# Altium schematic sheet Micro.SchDoc(Micro)
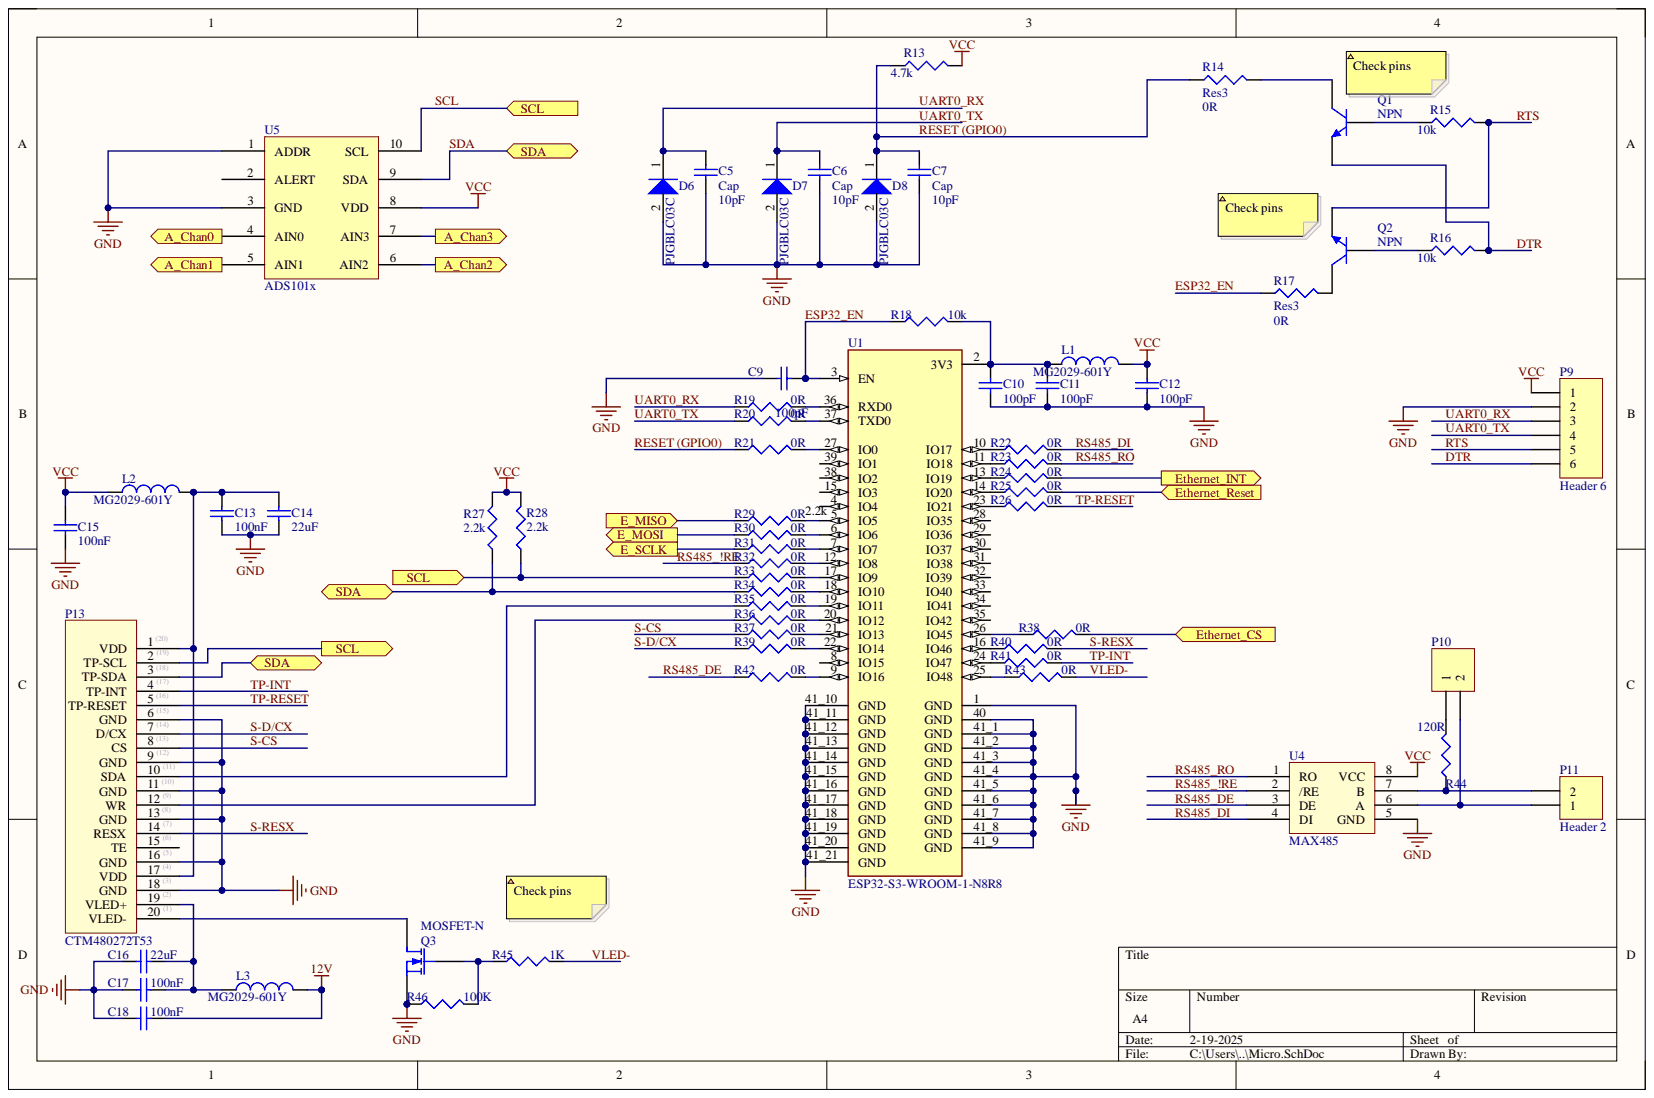
[diagram p0: Micro.SchDoc]

## Parts
C5  Cap  value 10pF  [CAPC2012X04M]
C6  Cap  value 10pF  [CAPC2012X04M]
C7  Cap  value 10pF  [CAPC2012X04M]
C9  value 100pF  [CAPC2012X04M]  (hidden comment: Cap)
C10  value 100pF  [CAPC2012X04M]  (hidden comment: Cap)
C11  value 100pF  [CAPC2012X04M]  (hidden comment: Cap)
C12  value 100pF  [CAPC2012X04M]  (hidden comment: Cap)
C13  value 100nF  [CAPC2012X04M]  (hidden comment: Cap)
C14  value 22uF  [CAPC2012X04M]  (hidden comment: Cap)
C15  value 100nF  [CAPC2012X04M]  (hidden comment: Cap)
C16  value 22uF  [CAPC2012X04M]  (hidden comment: Cap)
C17  value 100nF  [CAPC2012X04M]  (hidden comment: Cap)
C18  value 100nF  [CAPC2012X04M]  (hidden comment: Cap)
D6  PJGBLC03C  [DIOC2012X04M]
D7  PJGBLC03C  [DIOC2012X04M]
D8  PJGBLC03C  [DIOC2012X04M]
L1  value MG2029-601Y  [RESC2012X04M]  (hidden comment: Inductor)
L2  value MG2029-601Y  [RESC2012X04M]  (hidden comment: Inductor)
L3  value MG2029-601Y  [RESC2012X04M]  (hidden comment: Inductor)
P9  Header 6  [HDR1X6]
P10  Header 2  [HDR1X2]  (value not drawn)
P11  Header 2  [WURTH- WR-TBL 2pin 5mmpitch]
P13  CTM480272T53  [CF31201D0R0-05-NH]
Q1  NPN  [SOT95P245X110-3N]
Q2  NPN  [SOT95P245X110-3N]
Q3  MOSFET-N  [SOT95P245X110-3N]
R13  value 4.7k  [RESC2012X04M]  (hidden comment: Res3)
R14  Res3  value 0R  [RESC2012X04M]
R15  value 10k  [RESC2012X04M]  (hidden comment: Res3)
R16  value 10k  [RESC2012X04M]  (hidden comment: Res3)
R17  Res3  value 0R  [RESC2012X04M]
R18  value 10k  [RESC2012X04M]  (hidden comment: Res3)
R19  value 0R  [RESC2012X04M]  (hidden comment: Res3)
R20  value 0R  [RESC2012X04M]  (hidden comment: Res3)
R21  value 0R  [RESC2012X04M]  (hidden comment: Res3)
R22  value 0R  [RESC2012X04M]  (hidden comment: Res3)
R23  value 0R  [RESC2012X04M]  (hidden comment: Res3)
R24  value 0R  [RESC2012X04M]  (hidden comment: Res3)
R25  value 0R  [RESC2012X04M]  (hidden comment: Res3)
R26  value 0R  [RESC2012X04M]  (hidden comment: Res3)
R27  value 2.2k  [RESC2012X04M]  (hidden comment: Res3)
R28  value 2.2k  [RESC2012X04M]  (hidden comment: Res3)
R29  value 0R  [RESC2012X04M]  (hidden comment: Res3)
R30  value 0R  [RESC2012X04M]  (hidden comment: Res3)
R31  value 0R  [RESC2012X04M]  (hidden comment: Res3)
R32  value 0R  [RESC2012X04M]  (hidden comment: Res3)
R33  value 0R  [RESC2012X04M]  (hidden comment: Res3)
R34  value 0R  [RESC2012X04M]  (hidden comment: Res3)
R35  value 0R  [RESC2012X04M]  (hidden comment: Res3)
R36  value 0R  [RESC2012X04M]  (hidden comment: Res3)
R37  value 0R  [RESC2012X04M]  (hidden comment: Res3)
R38  value 0R  [RESC2012X04M]  (hidden comment: Res3)
R39  value 0R  [RESC2012X04M]  (hidden comment: Res3)
R40  value 0R  [RESC2012X04M]  (hidden comment: Res3)
R41  value 0R  [RESC2012X04M]  (hidden comment: Res3)
R42  value 0R  [RESC2012X04M]  (hidden comment: Res3)
R43  value 0R  [RESC2012X04M]  (hidden comment: Res3)
R44  value 120R  [RESC2012X04M]  (hidden comment: Res3)
R45  value 1K  [RESC2012X04M]  (hidden comment: Res3)
R46  value 100K  [RESC2012X04M]  (hidden comment: Res3)
U1  ESP32-S3-WROOM-1-N8R8  [XCVR_ESP32S3WROOM1N8R8]
U4  MAX485  [SOIC127P600X155-8N]
U5  ADS101x  [VSSOP_10]

## Ports
A_Chan0, A_Chan1, A_Chan2, A_Chan3, E_MISO, E_MOSI, E_SCLK, Ethernet_CS, Ethernet_INT, Ethernet_Reset, SCL, SDA

## Nets
12V: C18.1 L3.2
GND: C5.1 C6.1 C7.1 C9.2 C10.2 C11.2 C12.2 C13.2 C14.2 C15.2 C16.2 C17.2 C18.2 D6.2 D7.2 D8.2 P9.2 P13.3(GND) P13.5(GND) P13.8(GND) P13.10(GND) P13.12(GND) P13.15(GND) Q3.3 R46.1 U1.1(GND) U1.40(GND) U1.41_1(GND) U1.41_2(GND) U1.41_3(GND) U1.41_4(GND) U1.41_5(GND) U1.41_6(GND) U1.41_7(GND) U1.41_8(GND) U1.41_9(GND) U1.41_10(GND) U1.41_11(GND) U1.41_12(GND) U1.41_13(GND) U1.41_14(GND) U1.41_15(GND) U1.41_16(GND) U1.41_17(GND) U1.41_18(GND) U1.41_19(GND) U1.41_20(GND) U1.41_21(GND) U4.5(GND) U5.1(ADDR) U5.3(GND)
VCC: C12.1 C15.1 L1.2 L2.1 P9.1 R13.2 R27.2 R28.2 U4.8(VCC) U5.8(VDD)
A_Chan0: U5.4(AIN0) ; port A_Chan0
A_Chan1: U5.5(AIN1) ; port A_Chan1
A_Chan2: U5.6(AIN2) ; port A_Chan2
A_Chan3: U5.7(AIN3) ; port A_Chan3
DTR: P9.6 Q1.3 R16.2
E_MISO: R29.1 ; port E_MISO
E_MOSI: R30.1 ; port E_MOSI
E_SCLK: R31.1 ; port E_SCLK
ESP32_EN: C9.1 R17.1 R18.1 U1.3(EN)
Ethernet_CS: R38.2 ; port Ethernet_CS
Ethernet_INT: R24.2 ; port Ethernet_INT
Ethernet_Reset: R25.2 ; port Ethernet_Reset
RESET (GPIO0): C7.2 D8.1 R13.1 R14.1 R21.1
RS485_!RE: R32.1 U4.2(/RE)
RS485_DE: R42.1 U4.3(DE)
RS485_DI: R22.2 U4.4(DI)
RS485_RO: R23.2 U4.1(RO)
RTS: P9.5 Q2.3 R15.2
S-CS: P13.13(CS) R37.1
S-D/CX: P13.14(D/CX) R39.1
S-RESX: P13.7(RESX) R40.2
SCL: P13.19(TP-SCL) R28.1 R33.1 U5.10(SCL) ; port SCL
SDA: P13.18(TP-SDA) R27.1 R34.1 U5.9(SDA) ; port SDA
TP-INT: P13.17(TP-INT) R41.2
TP-RESET: P13.16(TP-RESET) R26.2
UART0_RX: C5.2 D6.1 P9.3 R19.1
UART0_TX: C6.2 D7.1 P9.4 R20.1
VLED-: R43.2 R45.2
NetC10_1: C10.1 C11.1 L1.1 R18.2 U1.2(3V3)
NetC13_1: C13.1 C14.1 L2.2 P13.4(VDD) P13.20(VDD)
NetC16_1: C16.1 C17.1 L3.1 P13.2(VLED+)
NetP10_1: P10.1 R44.2
NetP10_2: P10.2 P11.1 U4.6(A)
NetP11_2: P11.2 R44.1 U4.7(B)
NetP13_1: P13.1(VLED-) Q3.1
NetP13_9: P13.9(WR) R36.1
NetP13_11: P13.11(SDA) R35.1
NetQ1_1: Q1.1 R14.2
NetQ1_2: Q1.2 R15.1
NetQ2_1: Q2.1 R17.2
NetQ2_2: Q2.2 R16.1
NetQ3_2: Q3.2 R45.1 R46.2
NetR19_2: R19.2 U1.36(RXD0)
NetR20_2: R20.2 U1.37(TXD0)
NetR21_2: R21.2 U1.27(IO0)
NetR22_1: R22.1 U1.10(IO17)
NetR23_1: R23.1 U1.11(IO18)
NetR24_1: R24.1 U1.13(IO19)
NetR25_1: R25.1 U1.14(IO20)
NetR26_1: R26.1 U1.23(IO21)
NetR29_2: R29.2 U1.5(IO5)
NetR30_2: R30.2 U1.6(IO6)
NetR31_2: R31.2 U1.7(IO7)
NetR32_2: R32.2 U1.12(IO8)
NetR33_2: R33.2 U1.17(IO9)
NetR34_2: R34.2 U1.18(IO10)
NetR35_2: R35.2 U1.19(IO11)
NetR36_2: R36.2 U1.20(IO12)
NetR37_2: R37.2 U1.21(IO13)
NetR38_1: R38.1 U1.26(IO45)
NetR39_2: R39.2 U1.22(IO14)
NetR40_1: R40.1 U1.16(IO46)
NetR41_1: R41.1 U1.24(IO47)
NetR42_2: R42.2 U1.9(IO16)
NetR43_1: R43.1 U1.25(IO48)

Not connected: P13 6(TE); U1 4(IO4) 8(IO15) 15(IO3) 28(IO35) 29(IO36) 30(IO37) 31(IO38) 32(IO39) 33(IO40) 34(IO41) 35(IO42) 38(IO2) 39(IO1); U5 2(ALERT)

## Library data of the parts
C5-C7 C10-C18 = Cap: Capacitor; Component Kind: Standard; LatestRevisionDate: 17-Jul-2002; LatestRevisionNote: Re-released for DXP Platform.; Library Name: Miscellaneous Devices.IntLib; PackageDescription: Radial Cap, Thru-Hole; 2 Leads; 0.3 in Pin Spacing; PackageReference: RAD-0.3; Published: 8-Jun-2000; Signal Integrity: Cap; Simulation: CAP; Publisher: Altium Limited
C9 = Cap: Capacitor; Value: 100pF; Component Kind: Standard; LatestRevisionDate: 17-Jul-2002; LatestRevisionNote: Re-released for DXP Platform.; Library Name: Miscellaneous Devices.IntLib; PackageDescription: Radial Cap, Thru-Hole; 2 Leads; 0.3 in Pin Spacing; PackageReference: RAD-0.3; Published: 8-Jun-2000; Signal Integrity: Cap; Simulation: CAP; Publisher: Altium Limited
D6-D8 = SP4021-01FTG: Manufacturer: Littelfuse; Component Kind: Standard; Library Name: Diodes-TVS.SchLib; Mounting Technology: Surface Mount; PackageReference: SOD-323-2; PartNumber: SP4021-01FTG
L1-L3 = Inductor: Code_IEC: 1005; Code_JEITA: 0402; Component Kind: Standard; Description: Inductor; LatestRevisionDate: 29-May-2009; LatestRevisionNote: IPC-7351 Footprint Added.; Library Name: Miscellaneous Devices.IntLib; PackageDescription: Chip Inductor; PackageReference: 0402-A; Published: 8-Jun-2000; Signal Integrity: Inductor; Simulation: INDUCTOR; Publisher: Altium Limited
P9 = Header 6: Header, 6-Pin; Component Kind: Standard; LatestRevisionDate: 17-Jul-2002; LatestRevisionNote: Re-released for DXP Platform.; Library Name: Miscellaneous Connectors.IntLib; Signal Integrity: Connector; Publisher: Altium Limited
P10 P11 = Header 2: Header, 2-Pin; Component Kind: Standard; LatestRevisionDate: 17-Jul-2002; LatestRevisionNote: Re-released for DXP Platform.; Library Name: Miscellaneous Connectors.IntLib; Signal Integrity: Connector; Publisher: Altium Limited
Q1 Q2 = NPN: NPN Bipolar Transistor; Code_JEDEC: TO-226-AA; Component Kind: Standard; LatestRevisionDate: 17-Jul-2002; LatestRevisionNote: Re-released for DXP Platform.; Library Name: Miscellaneous Devices.IntLib; PackageDescription: TO, Flat Index; 3 In-Line, Axial Leads; Body Dia. 4.8mm; Leads 0.55 x 0.51 mm (max); PackageReference: TO-226-AA; Published: 8-Jun-2000; Signal Integrity: NPN; Simulation: NPN; Publisher: Altium Limited
Q3 = MOSFET-N: N-Channel MOSFET; Component Kind: Standard; LatestRevisionDate: 17-Jul-2002; LatestRevisionNote: Re-released for DXP Platform.; Library Name: Miscellaneous Devices.IntLib; PackageDescription: TO, Flat Index; 3 In-Line, Axial Leads; Body Dia. 4.6mm; Lead Dia. 0.5 mm (max); PackageReference: E3; PackageVersion: 1995/1996; Published: 8-Jun-2000; Simulation: NMOS; Publisher: Altium Limited
R13-R46 = Res3: Resistor; Component Kind: Standard; LatestRevisionDate: 29-May-2009; LatestRevisionNote: IPC-7351 Footprint Added.; Library Name: Miscellaneous Devices.IntLib; PackageDescription: Chip Resistor; PackageReference: J1-0603; Published: 8-Jun-2000; Signal Integrity: Res; Simulation: RESISTOR; Publisher: Altium Limited
U1 = ESP32-S3-WROOM-1-N8R8: Bluetooth, WiFi 802.11b/g/n, Bluetooth v5.0 Transceiver Module 2.4GHz PCB Trace Surface Mount; MF: Espressif Systems; Availability: In Stock; Check_prices: https://www.snapeda.com/parts/ESP32-S3-WROOM-1-N8R8/Espressif+Systems/view-part/?ref=eda; Component Kind: Standard; Library Name: ESP32-S3-WROOM-1-N8R8.SchLib; MP: ESP32-S3-WROOM-1-N8R8; Package: None; Price: None; Purchase-URL: https://pricing.snapeda.com/search?q=ESP32-S3-WROOM-1-N8R8&ref=eda; SnapEDA_Link: https://www.snapeda.com/parts/ESP32-S3-WROOM-1-N8R8/Espressif+Systems/view-part/?ref=snap
P13 = CTM480272T53: Component Kind: Standard; Library Name: Parts.SchLib
U4 = MAX485: Component Kind: Standard; Library Name: Parts.SchLib
U5 = ADS101x: Component Kind: Standard; Library Name: Parts.SchLib
